# Altium schematic sheet 04power.SchDoc
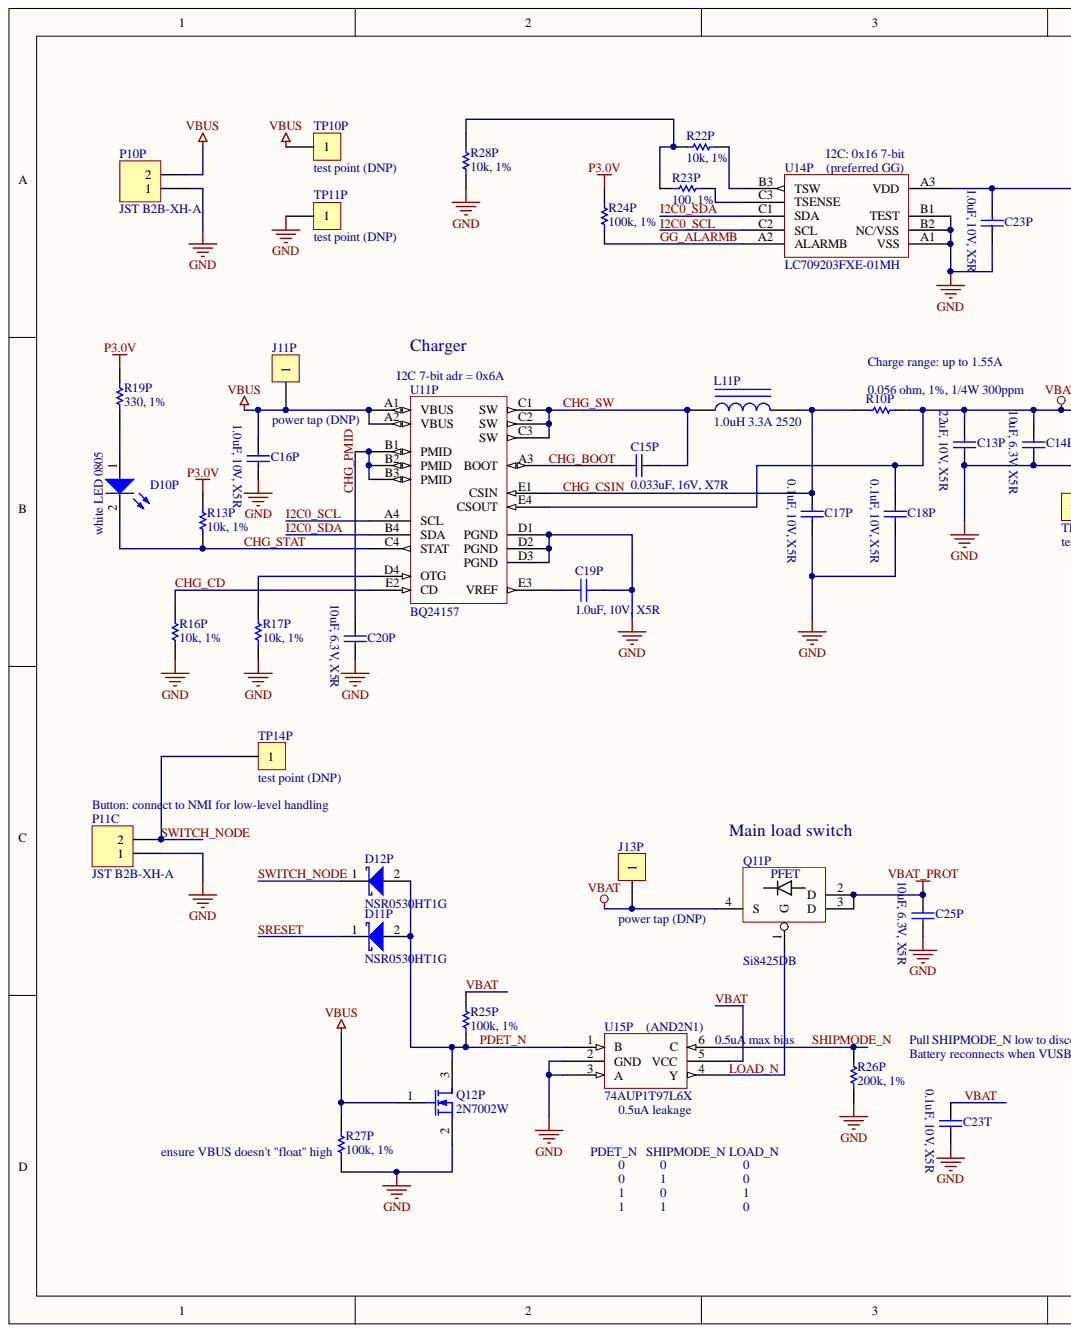
[diagram p0: 04power.SchDoc, left]
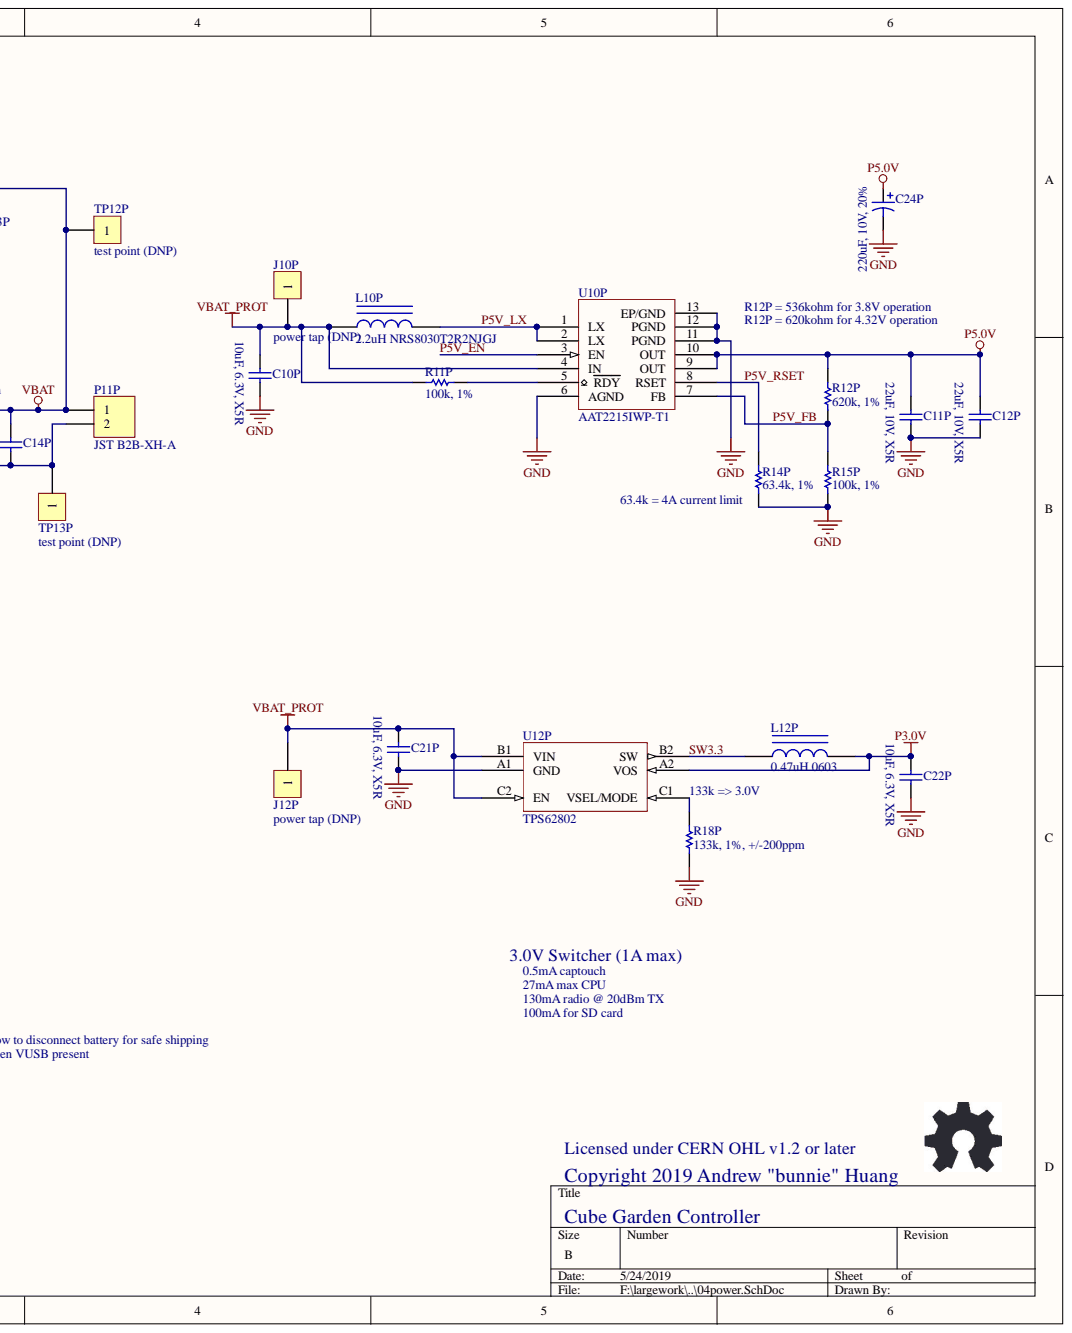
[diagram p1: 04power.SchDoc, right]

## Parts
C10P  10uF, 6.3V, X5R  [B_CAP0603]
C11P  22uF, 10V, X5R  [CAPC2012N]
C12P  22uF, 10V, X5R  [CAPC2012N]
C13P  22uF, 10V, X5R  [CAPC2012N]
C14P  10uF, 6.3V, X5R  [B_CAP0603]
C15P  0.033uF, 16V, X7R  [B_RES0402]
C16P  1.0uF, 10V, X5R  [B_CAP0402]
C17P  0.1uF, 10V, X5R  [B_CAP0402]
C18P  0.1uF, 10V, X5R  [B_CAP0402]
C19P  1.0uF, 10V, X5R  [B_CAP0402]
C20P  10uF, 6.3V, X5R  [B_CAP0603]
C21P  10uF, 6.3V, X5R  [B_CAP0603]
C22P  10uF, 6.3V, X5R  [B_CAP0603]
C23P  1.0uF, 10V, X5R  [B_CAP0402]
C23T  0.1uF, 10V, X5R  [B_CAP0402]
C24P  220uF, 10V, 20%  [CAPAE7066X61N]
C25P  10uF, 6.3V, X5R  [B_CAP0603]
D10P  white LED 0805  [CD2012-0805]
D11P  NSR0530HT1G  [SOD-323]
D12P  NSR0530HT1G  [SOD-323]
J10P  power tap (DNP)  [RESTAP-0603]
J11P  power tap (DNP)  [RESTAP-0603]
J12P  power tap (DNP)  [RESTAP-0603]
J13P  power tap (DNP)  [RESTAP-0603]
L10P  2.2uH NRS8030T2R2NJGJ  MPN: NRS8030T2R2NJGJ  [B_IND8030_TAIYO]
L11P  1.0uH 3.3A 2520  [B_IND2025_SAM]
L12P  0.47uH 0603  [B_IND0603]
P10P  JST B2B-XH-A  VENDOR: JST  [JST_B2B-XH-A]
P11C  JST B2B-XH-A  VENDOR: JST  [JST_B2B-XH-A]
P11P  JST B2B-XH-A  VENDOR: JST  [JST_B2B-XH-A]
Q11P  Si8425DB  [VISHAY_MICRO_FOOT_4_BUMP]
Q12P  2N7002W  [SOT323-3AN]
R10P  0.056 ohm, 1%, 1/4W 300ppm  [B_RES0402]
R11P  100k, 1%  [B_RES0402]
R12P  620k, 1%  [B_RES0402]
R13P  10k, 1%  [B_RES0402]
R14P  63.4k, 1%  [B_RES0402]
R15P  100k, 1%  [B_RES0402]
R16P  10k, 1%  [B_RES0402]
R17P  10k, 1%  [B_RES0402]
R18P  133k, 1%, +/-200ppm  [B_RES0402]
R19P  330, 1%  [B_RES0402]
R22P  10k, 1%  [B_RES0402]
R23P  100, 1%  [B_RES0402]
R24P  100k, 1%  [B_RES0402]
R25P  100k, 1%  [B_RES0402]
R26P  200k, 1%  [B_RES0402]
R27P  100k, 1%  [B_RES0402]
R28P  10k, 1%  [B_RES0402]
TP10P  test point (DNP)  [TP_2MM_SQ]
TP11P  test point (DNP)  [TP_2MM_SQ]
TP12P  test point (DNP)  [TP_2MM_SQ]
TP13P  test point (DNP)  [TP_2MM_SQ]
TP14P  test point (DNP)  [TP_2MM_SQ]
U10P  AAT2215IWP-T1  [SKYWORKS_SON45P300X80_HS-13N]
U11P  BQ24157  [BGA20C40P4X5_200X214X62]
U12P  TPS62802  [TI_YKA0006]
U14P  LC709203FXE-01MH  [WLCSP9_ONSEMI_BGA9C50P3X3_176X160X51]
U15P  74AUP1T97L6X  [FSC-L6X-PSON50P100X55-6N]

## Nets
GND: C10P.2 C11P.2 C12P.2 C13P.2 C14P.2 C16P.2 C17P.2 C18P.2 C19P.2 C20P.2 C21P.2 C22P.2 C23P.2 C23T.2 C24P.2 C25P.2 P10P.1 P11C.1 P11P.2 Q12P.2 R14P.2 R15P.2 R16P.2 R17P.2 R18P.1 R26P.2 R27P.2 R28P.2 TP11P.1 TP13P.1 U10P.6(AGND) U10P.11(PGND) U10P.12(PGND) U10P.13(EP/GND) U11P.D1(PGND) U11P.D2(PGND) U11P.D3(PGND) U12P.A1(GND) U14P.A1(VSS) U14P.B1(TEST) U14P.B2(NC/VSS) U15P.2(GND) U15P.3(A)
P3.0V: C22P.1 L12P.2 R13P.1 R19P.2 R24P.1 U12P.A2(VOS)
VBAT_PROT: C10P.1 C21P.1 C25P.1 J10P.1 J12P.1 L10P.1 Q11P.2(D) Q11P.3(D) R11P.1 U10P.4(IN) U12P.B1(VIN) U12P.C2(EN)
VBUS: C16P.1 J11P.1 P10P.2 Q12P.1 R27P.1 TP10P.1 U11P.A1(VBUS) U11P.A2(VBUS)
CHG_BOOT: C15P.2 U11P.A3(BOOT)
CHG_CD: R16P.1 U11P.E2(CD)
CHG_CSIN: C17P.1 L11P.2 R10P.2 U11P.E1(CSIN)
CHG_PMID: C20P.1 U11P.B1(PMID) U11P.B2(PMID) U11P.B3(PMID)
CHG_STAT: D10P.2 R13P.2 U11P.C4(STAT)
CHG_SW: C15P.1 L11P.1 U11P.C1(SW) U11P.C2(SW) U11P.C3(SW)
GG_ALARMB: R24P.2 U14P.A2(ALARMB)
I2C0_SCL: U11P.A4(SCL) U14P.C2(SCL)
I2C0_SDA: U11P.B4(SDA) U14P.C1(SDA)
LOAD_N: Q11P.1(G) U15P.4(Y)
P5.0V: C11P.1 C12P.1 C24P.1 L10P.2 R12P.1 R12P.2 R14P.1 R15P.1 U10P.1(LX) U10P.2(LX) U10P.3(EN) U10P.7(FB) U10P.8(RSET) U10P.9(OUT) U10P.10(OUT)  [also labelled P5V_EN, P5V_FB, P5V_LX, P5V_RSET]
PDET_N: D11P.2 D12P.2 Q12P.3 R25P.2 U15P.1(B)
SHIPMODE_N: R26P.1 U15P.6(C)
SRESET: D11P.1
SW3.3: L12P.1 U12P.B2(SW)
SWITCH_NODE: D12P.1 P11C.2 TP14P.1
VBAT: C13P.1 C14P.1 C18P.1 C23P.1 C23T.1 J13P.1 P11P.1 Q11P.4(S) R10P.1 R25P.1 TP12P.1 U11P.E4(CSOUT) U14P.A3(VDD) U15P.5(VCC)
NetC19P_1: C19P.1 U11P.E3(VREF)
NetD10P_1: D10P.1 R19P.1
NetR11P_2: R11P.2 U10P.5(RDY)
NetR17P_1: R17P.1 U11P.D4(OTG)
NetR18P_2: R18P.2 U12P.C1(VSEL/MODE)
NetR22P_1: R22P.1 R23P.1 R28P.1
NetR22P_2: R22P.2 U14P.B3(TSW)
NetR23P_2: R23P.2 U14P.C3(TSENSE)

## Library data of the parts
C10P C14P C20P C21P C22P C25P = Cap Semi: Capacitor (Semiconductor SIM Model); MPN: CL10A106MQ8NNNC; MYPN: C-106-6.3-X5R-0603; VENDOR: Samsung; Component Kind: Standard; Library Name: Miscellaneous Devices.IntLib; Signal Integrity: Cap Semi; Simulation: CAP
C11P C12P C13P = Cap Semi: Capacitor (Semiconductor SIM Model); MPN: CL21A226KPCLRNC; MYPN: C-226-10-X5R-0805; VENDOR: Samsung; Component Kind: Standard; Library Name: Miscellaneous Devices.IntLib; Signal Integrity: Cap Semi; Simulation: CAP
C15P = Cap Semi: Capacitor (Semiconductor SIM Model); MPN: CL05B333KO5NNNC; MYPN: C-333-16-X7R-0402; VENDOR: Samsung; Component Kind: Standard; Library Name: Miscellaneous Devices.IntLib; Signal Integrity: Cap Semi; Simulation: CAP
C16P C19P C23P = Cap Semi: Capacitor (Semiconductor SIM Model); MPN: CL05A105KP5NNND; MYPN: C-105-10-X5R-0402; VENDOR: Samsung; Component Kind: Standard; Library Name: Miscellaneous Devices.IntLib; Signal Integrity: Cap Semi; Simulation: CAP
C17P C18P C23T = Cap Semi: Capacitor (Semiconductor SIM Model); MPN: CL05A104KP5NNNC; MYPN: C-104-10-X5R-0402; VENDOR: Samsung; Component Kind: Standard; Library Name: Miscellaneous Devices.IntLib; Signal Integrity: Cap Semi; Simulation: CAP
C24P = Cap Pol1: Polarized Capacitor (Radial); MPN: UUR1A221MCL6GS; MYPN: C-227-10-UCL-6.3x8.0; VENDOR: Nichicon; Component Kind: Standard; Library Name: Miscellaneous Devices.IntLib; Signal Integrity: Cap Pol; Simulation: CAP
D10P = LED2: White LED; MPN: CB-2012QWC-P8; MYPN: D-LED-WHITE-0805; VENDOR: Cheng Guang Xing; Component Kind: Standard; LatestRevisionDate: 17-Jul-2002; LatestRevisionNote: Re-released for DXP Platform.; Library Name: Miscellaneous Devices.IntLib; PackageReference: 3.2X1.6X1.1; PackageVersion: Feb-2002; Published: 8-Jun-2000; Simulation: LED2; Publisher: Altium Limited
D11P D12P = D Schottky: Schottky Diode; MPN: NSR0530H-D; MYPN: D-S-0p5-30-0p37-SOD323; VENDOR: Semtech HK LTD; Component Kind: Standard; Library Name: Miscellaneous Devices.IntLib; Revision: July-2002: Re-released for DXP Platform.; Signal Integrity: D Schottky; Simulation: SKYDIODE
J10P J11P J12P J13P = CON1: Connector; MYPN: J-TP-PWRTAP-0603; Component Kind: Standard; MPN: DNP; VENDOR: DNP
L10P = Inductor Iron: Magnetic-Core Inductor; MYPN: L-NRS8030T2R2NJGJ; VENDOR: Taiyo Yuden; Component Kind: Standard; Library Name: Miscellaneous Devices.IntLib; Signal Integrity: Inductor Iron; Simulation: INDUCTOR
L11P = Inductor Iron: Magnetic-Core Inductor; MPN: CIGW252010GL1R0MNE; MYPN: L-CIGW252010GL1R0MNE; VENDOR: Samsung; Component Kind: Standard; Library Name: Miscellaneous Devices.IntLib; Signal Integrity: Inductor Iron; Simulation: INDUCTOR
L12P = Inductor Iron: Magnetic-Core Inductor; MPN: TFM160808ALC-R47MTAA; MYPN: L-TFM160808ALC-R47MTAA; VENDOR: TDK; Component Kind: Standard; Library Name: Miscellaneous Devices.IntLib; Signal Integrity: Inductor Iron; Simulation: INDUCTOR
P10P P11C P11P = Header 2: Header, 2-Pin; MPN: B2B-XH-A(LF)(SN); MYPN: J-2.5MM-JST-TOP-TH; Component Kind: Standard; LatestRevisionDate: 17-Jul-2002; LatestRevisionNote: Re-released for DXP Platform.; Library Name: Miscellaneous Connectors.IntLib; Signal Integrity: Connector; Publisher: Altium Limited
Q11P = Si8425DB: P-channel 20V 6.2A 27mOhm @ -2.5V; MPN: Si8425DB-T1-E1; MYPN: QSi8525; VENDOR: Vishay Siliconix; Component Kind: Standard; Library Name: temp-to-merge.SchLib
Q12P = MOSFET-N: N-Channel MOSFET; MPN: 2N7002WT1G; MYPN: Q-2N7002; VENDOR: On Semiconductor; Component Kind: Standard; LatestRevisionDate: 17-Jul-2002; LatestRevisionNote: Re-released for DXP Platform.; Library Name: Miscellaneous Devices.IntLib; PackageReference: E3; PackageVersion: 1995/1996; Published: 8-Jun-2000; Simulation: NMOS; Publisher: Altium Limited
R10P = Res1: Resistor; MPN: ERJ-2BWFR056X; MYPN: R-0R56-1P-1_4W-0402; VENDOR: Panasonic; Component Kind: Standard; Library Name: Miscellaneous Devices.IntLib; Signal Integrity: Res; Simulation: RESISTOR
R11P R15P R24P R25P R27P = Res1: Resistor; MPN: RS02U104FT; MYPN: R-104-1P-1_16W-0402; VENDOR: Fenghua; Component Kind: Standard; Library Name: Miscellaneous Devices.IntLib; Signal Integrity: Res; Simulation: RESISTOR
R12P = Res1: Resistor; MPN: RS02U6203FT; MYPN: R-6203-1P-1_16W-0402; VENDOR: Fenghua; Component Kind: Standard; Library Name: Miscellaneous Devices.IntLib; Signal Integrity: Res; Simulation: RESISTOR
R13P R16P R17P = Res1: Resistor; MPN: ANY; MYPN: R-103-1P-1_16W-0402; Component Kind: Standard; Library Name: Miscellaneous Devices.IntLib; Signal Integrity: Res; Simulation: RESISTOR; VENDOR: ANY
R14P = Res1: Resistor; MPN: ANY; MYPN: R-6342-1P-1_16W-0402; Component Kind: Standard; Library Name: Miscellaneous Devices.IntLib; Signal Integrity: Res; Simulation: RESISTOR; VENDOR: ANY
R18P = Res1: Resistor; MPN: ANY; MYPN: R-1333-1P-1_16W-0402; Component Kind: Standard; Library Name: Miscellaneous Devices.IntLib; Signal Integrity: Res; Simulation: RESISTOR; VENDOR: ANY
R19P = Res1: Resistor; MPN: RS02U331FT; MYPN: R-331-1P-1_16W-0402; VENDOR: Fenghua; Component Kind: Standard; Library Name: Miscellaneous Devices.IntLib; Signal Integrity: Res; Simulation: RESISTOR
R22P R28P = Res1: Resistor; MPN: RS02U103FT; MYPN: R-103-1P-1_16W-0402; VENDOR: Fenghua; Component Kind: Standard; Library Name: Miscellaneous Devices.IntLib; Signal Integrity: Res; Simulation: RESISTOR
R23P = Res1: Resistor; MPN: RS02U101FT; MYPN: R-101-1P-1_16W-0402; VENDOR: Fenghua; Component Kind: Standard; Library Name: Miscellaneous Devices.IntLib; Signal Integrity: Res; Simulation: RESISTOR
R26P = Res1: Resistor; MPN: RS02U204FT; MYPN: R-204-1P-1_16W-0402; VENDOR: Fenghua; Component Kind: Standard; Library Name: Miscellaneous Devices.IntLib; Signal Integrity: Res; Simulation: RESISTOR
TP10P TP11P TP12P TP13P TP14P = CON1: Connector; Component Kind: Standard
U10P = AAT2215IWP-T1: Skyworks High Current Synch Step-Up w/ Programmable Current Limit; MYPN: U-AAT2215IWP-T1; Component Kind: Standard; Library Name: commonsch-new.SchLib; MFG: Skyworks; MPN: AAT2215IWP-T1
U11P = BQ24157: Fully integrated switch-mode charger with USB compliance and OTG support; MPN: BQ24157YFF(R/T); MYPN: U-BQ24157YFFT; VENDOR: TI; Component Kind: Standard
U12P = TPS62802: Buck regulator, 4MHz, 0.6A, 1.8V-3.3V, low Iq; MPN: TPS62802YKA(R/T); MYPN: U-TPS62802YKAT; VENDOR: TI; Component Kind: Standard
U14P = LC709203FXE-01MH: Smart LiB Gauge for 1-cell Li-Ion; MFG: On Semiconductor; MYPN: U-LC709203FXE-01MH; Component Kind: Standard; Library Name: commonsch-new.SchLib; MPN: LC709203FXE-01MH
U15P = 74AUP1T97L6X: Low power configurable gate with voltage translator; MYPN: U74AUP1T97; VENDOR: Fairchild Semiconductor; Component Kind: Standard; Library Name: commonsch-new.SchLib; MPN: 74AUP1T97L6X
